# Altium schematic sheet SUPPLY Monitoring Circuit.SchDoc("SUPPLY Monitoring Circuit")
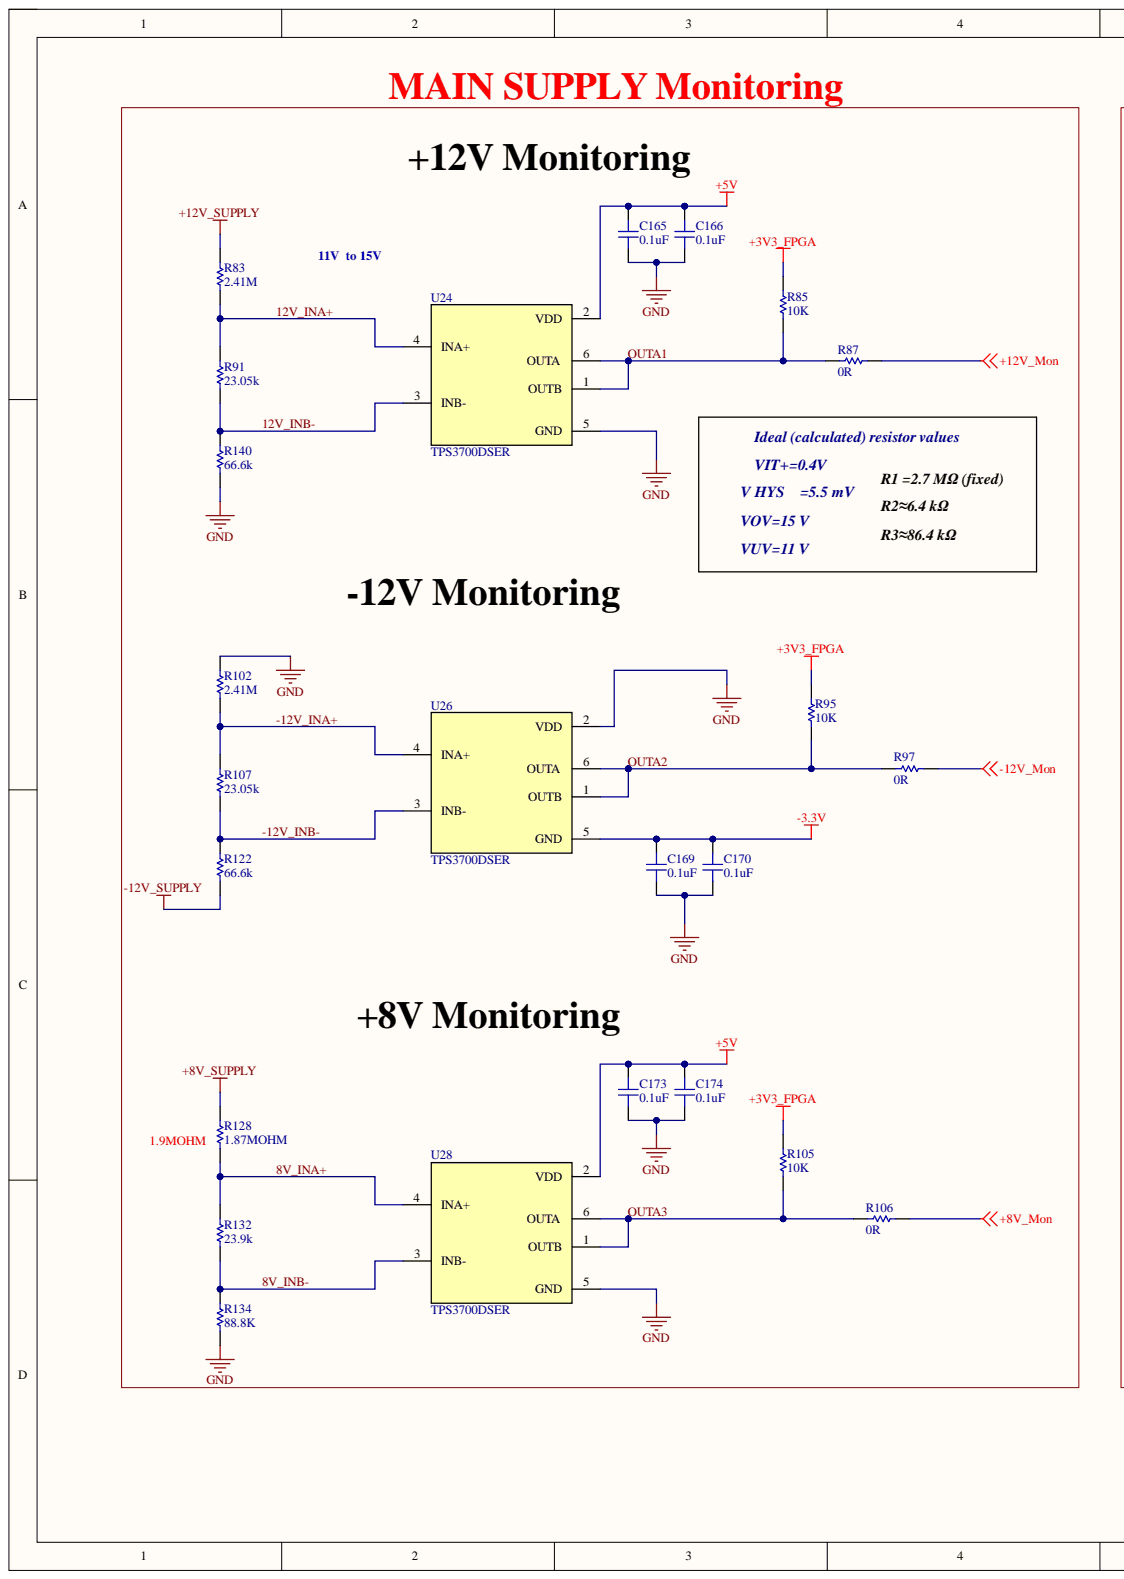
[diagram p0: SUPPLY Monitoring Circuit.SchDoc, left]
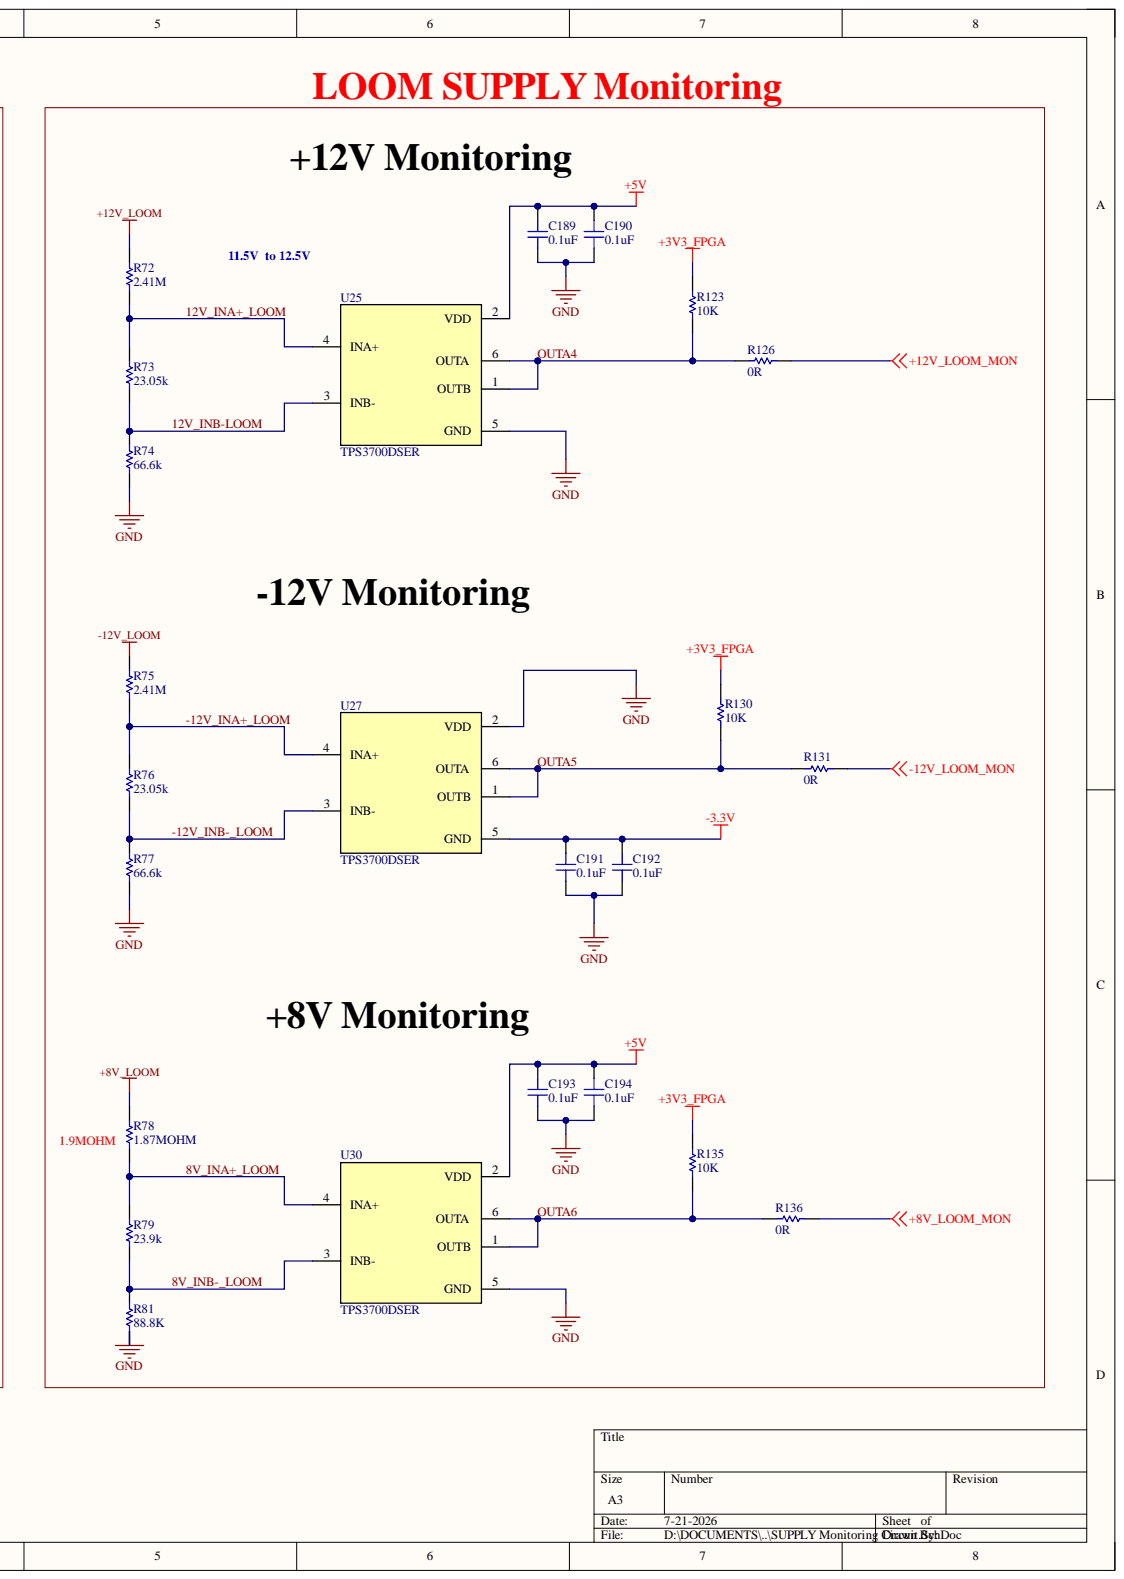
[diagram p1: SUPPLY Monitoring Circuit.SchDoc, right]

## Parts
C165  0.1uF  [CAP_0402]
C166  0.1uF  [CAP_0402]
C169  0.1uF  [CAP_0402]
C170  0.1uF  [CAP_0402]
C173  0.1uF  [CAP_0402]
C174  0.1uF  [CAP_0402]
C189  0.1uF  [CAP_0402]
C190  0.1uF  [CAP_0402]
C191  0.1uF  [CAP_0402]
C192  0.1uF  [CAP_0402]
C193  0.1uF  [CAP_0402]
C194  0.1uF  [CAP_0402]
R72  2.41M  [RES_0603]
R73  23.05k  [RES_0603]
R74  66.6k  [RES_0603]
R75  2.41M  [RES_0603]
R76  23.05k  [RES_0603]
R77  66.6k  [RES_0603]
R78  1.87MOHM  [RES_0603]
R79  23.9k  [RES_0603]
R81  88.8K  [RES_0603]
R83  2.41M  [RES_0603]
R85  10K  [RES_0402]
R87  0R  [RES_0402]
R91  23.05k  [RES_0603]
R95  10K  [RES_0402]
R97  0R  [RES_0402]
R102  2.41M  [RES_0603]
R105  10K  [RES_0402]
R106  0R  [RES_0402]
R107  23.05k  [RES_0603]
R122  66.6k  [RES_0603]
R123  10K  [RES_0402]
R126  0R  [RES_0402]
R128  1.87MOHM  [RES_0603]
R130  10K  [RES_0402]
R131  0R  [RES_0402]
R132  23.9k  [RES_0603]
R134  88.8K  [RES_0603]
R135  10K  [RES_0402]
R136  0R  [RES_0402]
R140  66.6k  [RES_0603]
U24  TPS3700DSER  [DSE0006A]
U25  TPS3700DSER  [DSE0006A]
U26  TPS3700DSER  [DSE0006A]
U27  TPS3700DSER  [DSE0006A]
U28  TPS3700DSER  [DSE0006A]
U30  TPS3700DSER  [DSE0006A]

## Nets
+3V3_FPGA: R85.2 R95.2 R105.2 R123.2 R130.2 R135.2
+5V: C165.2 C166.2 C173.2 C174.2 C189.2 C190.2 C193.2 C194.2 U24.2(VDD) U25.2(VDD) U28.2(VDD) U30.2(VDD)
-3.3V: C169.2 C170.2 C191.2 C192.2 U26.5(GND) U27.5(GND)
-12V_LOOM: R75.1
-12V_LOOM_MON: R131.2
-12V_Mon: R97.2
-12V_SUPPLY: R122.2
GND: C165.1 C166.1 C169.1 C170.1 C173.1 C174.1 C189.1 C190.1 C191.1 C192.1 C193.1 C194.1 R74.2 R77.2 R81.2 R102.1 R134.2 R140.2 U24.5(GND) U25.5(GND) U26.2(VDD) U27.2(VDD) U28.5(GND) U30.5(GND)
8V_INA+: R78.1 R106.2 R128.1 R128.2 R132.1 R136.2 U28.4(INA+)
8V_INA+_LOOM: R78.2 R79.1 U30.4(INA+)
8V_INB-: R132.2 R134.1 U28.3(INB-)
8V_INB-_LOOM: R79.2 R81.1 U30.3(INB-)
12V_INA+: R72.1 R83.1 R83.2 R87.2 R91.1 R126.2 U24.4(INA+)
12V_INA+_LOOM: R72.2 R73.1 U25.4(INA+)
12V_INB-: R91.2 R140.1 U24.3(INB-)
12V_INB-LOOM: R73.2 R74.1 U25.3(INB-)
-12V_INA+: R102.2 R107.1 U26.4(INA+)
-12V_INA+_LOOM: R75.2 R76.1 U27.4(INA+)
-12V_INB-: R107.2 R122.1 U26.3(INB-)
-12V_INB-_LOOM: R76.2 R77.1 U27.3(INB-)
OUTA1: R85.1 R87.1 U24.1(OUTB) U24.6(OUTA)
OUTA2: R95.1 R97.1 U26.1(OUTB) U26.6(OUTA)
OUTA3: R105.1 R106.1 U28.1(OUTB) U28.6(OUTA)
OUTA4: R123.1 R126.1 U25.1(OUTB) U25.6(OUTA)
OUTA5: R130.1 R131.1 U27.1(OUTB) U27.6(OUTA)
OUTA6: R135.1 R136.1 U30.1(OUTB) U30.6(OUTA)

## Library data of the parts
C165 C166 C169 C170 C173 C174 C189-C194 = CAP_0402_25V: C0402_25V; Component Kind: Standard; Library Name: ANAND_LIB.SchLib
R72-R79 R81 R83 R91 R102 R107 R122 R128 R132 R134 R140 = RES_0603: R0603; Component Kind: Standard; Library Name: MAX10.SchLib
R85 R95 R105 R123 R130 R135 = RES_0402: Thick Film Resistors - SMD 1/16watt 50Kohms 1% Non Standard; Component Kind: Standard; Library Name: ANAND_LIB.SchLib
R87 R97 R106 R126 R131 R136 = RES_0402: R0402; Component Kind: Standard; Library Name: FPGA_LIB.SchLib
U24-U28 U30 = TPS3700DSER: High-voltage (18-V) window voltage detector for overvoltage and undervoltage monitoring 6-WSON -40 to 125; Manufacturer_Name: Texas Instruments; Component Kind: Standard; Datasheet: https://www.ti.com/lit/gpn/tps3700; Manufacturer_Part_Number: TPS3700DSER; RefDes: RefDes; TYPE: TYPE; Copyright: Copyright (C) 2025 Ultra Librarian. All rights reserved.; Library Name: ANAND_LIB.SchLib
